# Altium schematic sheet Sheet2.SchDoc(Sheet2)
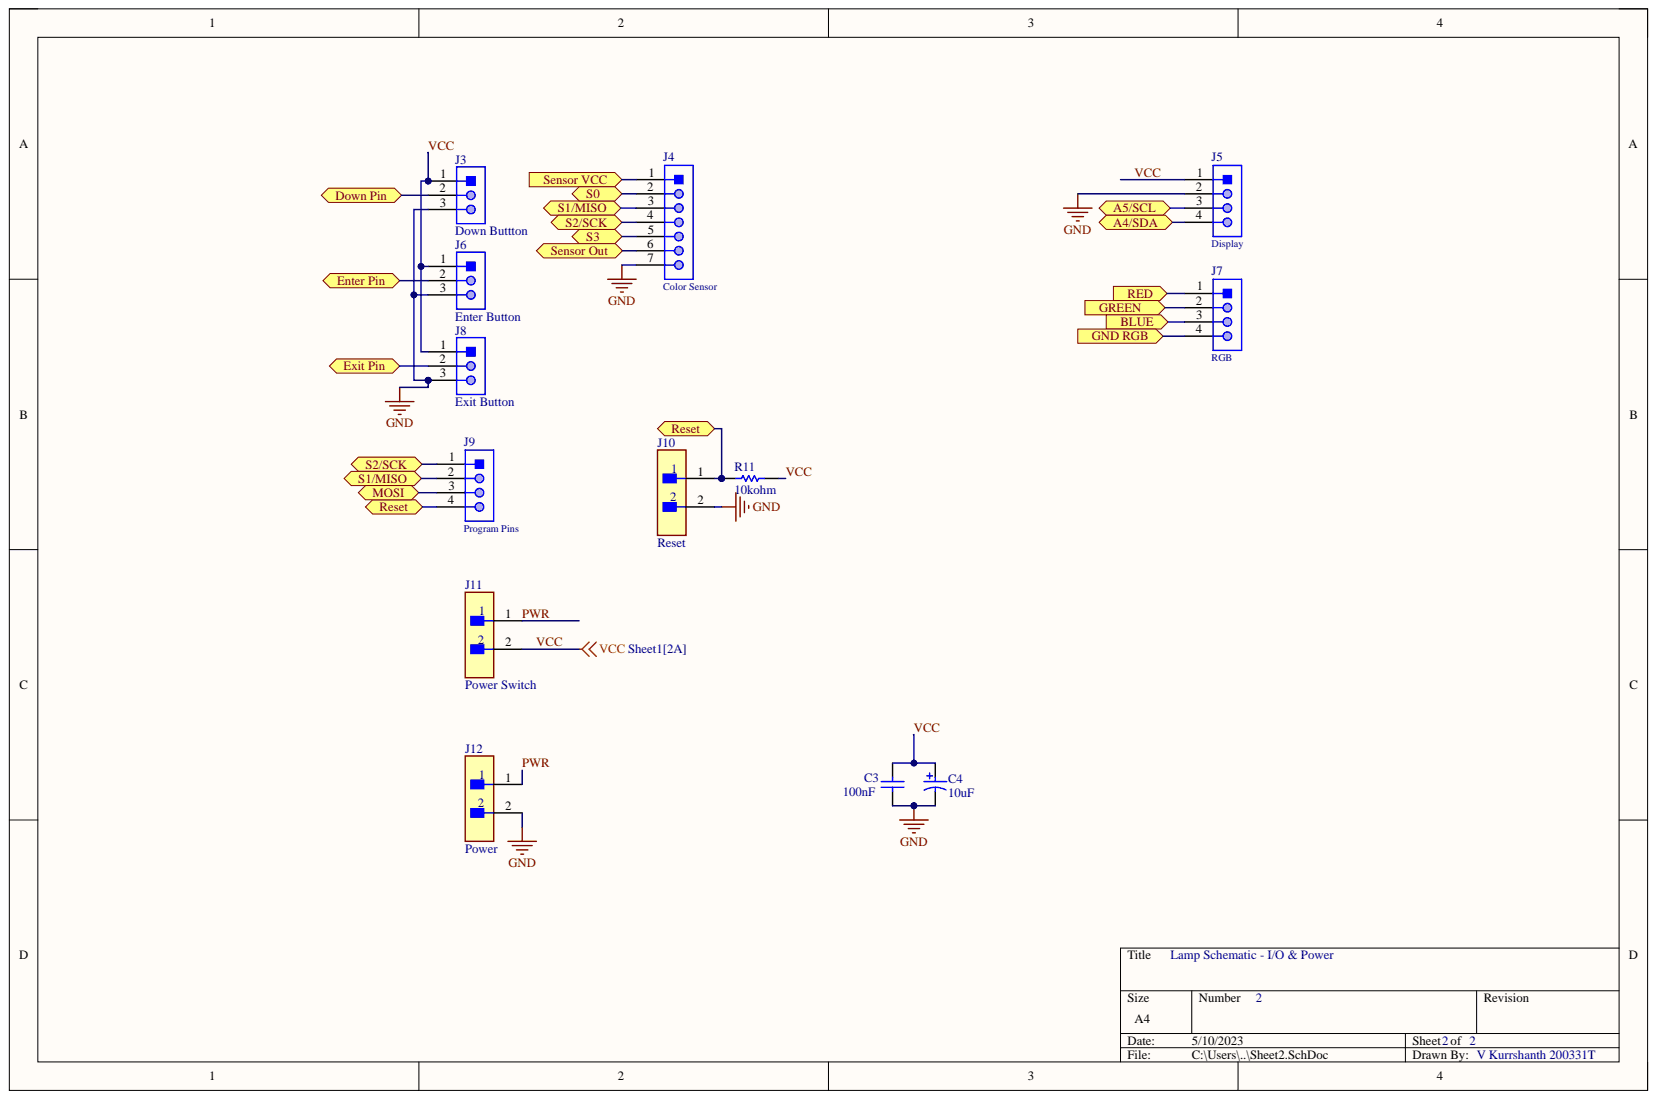
[diagram p0: Sheet2.SchDoc]

## Parts
C3  100nF  [VISH-K104K15X7RF5TL2]
C4  10uF  [CAPPR55-200-1100X500X1200]
J3  Down Buttton  [MOLX-22-28-4033_V]
J4  Color Sensor  [61300711121]
J5  Display  [61300411121]
J6  Enter Button  [MOLX-22-28-4033_V]
J7  RGB  [61300411121]
J8  Exit Button  [MOLX-22-28-4033_V]
J9  Program Pins  [61300411121]
J10  Reset  [FP-M20-9990246-MFG]
J11  Power Switch  [FP-M20-9990246-MFG]
J12  Power  [FP-M20-9990246-MFG]
R11  10kohm  [RESA60-630X240]

## Ports
A4/SDA, A5/SCL, BLUE, Down Pin, Enter Pin, Exit Pin, GND RGB, GREEN, MOSI, RED, Reset, S0, S1/MISO, S2/SCK, S3, Sensor Out, Sensor VCC

## Nets
GND: C3.1 C4.2 J3.3 J4.7 J5.2 J6.3 J8.3 J10.2 J12.2
A4/SDA: J5.4 ; port A4/SDA
A5/SCL: J5.3 ; port A5/SCL
BLUE: J7.3 ; port BLUE
Down Pin: J3.2 ; port Down Pin
Enter Pin: J6.2 ; port Enter Pin
Exit Pin: J8.2 ; port Exit Pin
GND RGB: J7.4 ; port GND RGB
GREEN: J7.2 ; port GREEN
MOSI: J9.3 ; port MOSI
PWR: J11.1 J12.1
RED: J7.1 ; port RED
Reset: J9.4 J10.1 R11.1 ; port Reset
S0: J4.2 ; port S0
S1/MISO: J4.3 J9.2 ; port S1/MISO
S2/SCK: J4.4 J9.1 ; port S2/SCK
S3: J4.5 ; port S3
Sensor Out: J4.6 ; port Sensor Out
Sensor VCC: J4.1 ; port Sensor VCC
VCC: C3.2 C4.1 J3.1 J5.1 J6.1 J8.1 J11.2 R11.2

## Library data of the parts
C3 = CMP-2000-06508-1: Ceramic Capacitor, 100 nF, +/- 10%, 50 V, -55 to 125 degC, 2-Pin THD, RoHS, Tape and Reel; Manufacturer: Vishay; Capacitance: 0.1µF; Catalog Drawings: Mono-KapSide2-15_5.58Mono-KapSide1_15; Online Catalog: Mono-Kap™Series; Other Names: BC1084CT; Temperature Coefficient: X7R; Voltage - Rated: 50V; Applications: GeneralPurpose; Category: Capacitors; Component Kind: Standard; Datasheet URL: http://www.vishay.com/docs/45171/kseries.pdf; Datasheet Version: 08/2013; Family: CeramicCapacitors; Features: -; Height - Seated (Max): 0.220"(5.58mm); Lead Spacing: 0.098"(2.50mm); Lead Style: Straight; Library Name: Altium Content Vault; Manufacturer URL: http://www.vishay.com/; Mounting Technology: ThroughHole; Mounting Type: ThroughHole; Operating Temperature: -55°C~125°C; Package / Case: Radial; Package Description: 2-Pin Through Hole Device, Body 4 x 2.6 mm; Package Reference: K104K15X7RF5TL2; Packaging: CutTape(CT); Ratings: -; Series: Mono-Kap™K; Size / Dimension: 0.157"Lx0.098"W(4.00mmx2.50mm); Standard Package: 1; Thickness (Max): -; Tolerance: ±10%
C4 = CMP-1665-00038-2: Aluminum Electrolytic Capacitor, 10 uF, +/- 20%, 50 V, -40 to 85 degC, 2-Pin THD, RoHS, Bulk; Manufacturer: Panasonic; Dielectric Material: Aluminium; Dissipation Factor: 0.12%; Lead Diameter: 0.5mm; Lead/Base Style: Straight; Leakage Current: 3uA; Life (Hours): 2000Hour; Ripple Current: 65mA; Voltage: 50V; Capacitance: 10uF; Case/Package: Radial; Component Kind: Standard; Datasheet URL: https://industrial.panasonic.com/cdbs/www-data/pdf/RDF0000/ABA0000C1218.pdf; Datasheet Version: Rev. 05, 10/2016; Diameter: 5mm; Height: 11mm; Height - Seated (Max): 0.472inch; Lead Free: LeadFree; Lead Pitch: 2mm; Length: 11mm; Library Name: Altium Content Vault; Manufacturer URL: http://www.panasonic.com/; Max Operating Temperature: 85degC; Min Operating Temperature: -40degC; Mount: ThroughHole; Number of Pins: 2; Package Description: 2-Pin Radial Lead, Dia 5 mm, Height 12 mm; Package Quantity: 200; Package Reference: CAPPR55-200-1100X500X1200; Packaging: Bulk; Radiation Hardening: No; REACH SVHC: NoSVHC; Ripple Current (AC): 65mA; RoHSCompliant: true; Termination: Radial; Tolerance: 20%; Voltage Rating: 50V; Voltage Rating (DC): 50V
J3 J6 J8 = CMP-1676-00001-5: Male Header, Pitch 2.54 mm, 1 x 3 Position, Height 8.38 mm, Tail Length 3.18 mm, RoHS, Bulk; Manufacturer: Molex; Current Rating: 4 A; Rows: 1; Voltage Rating: 250 V; Colour: Black; Component Kind: Standard; ComponentLink1Description: Manufacturer URL; ComponentLink1URL: http://www.molex.com/; ComponentLink2Description: Datasheet; ComponentLink2URL: http://www.molex.com/pdm_docs/sd/022284033_sd.pdf; Contact Finish: Gold; Contact Material: Brass; Contact Plating: Gold; DatasheetVersion: Rev. G, 01/2012; Height: 8.38 mm; Lead Pitch: 0.1 inch; Length: 7.62 mm; Library Name: Altium Content Vault; Max Operating Temperature: 75 degC; Min Operating Temperature: 0 degC; Mount: Through Hole; Mounting Technology: Through Hole; Package Quantity: 1; PackageDescription: Male Header, Pitch 2.54 mm, 1 x 3 Position, Height 8.38 mm, Tail Length 3.18 mm; PackageReference: 22-28-4033; Packaging: Bulk; Pins: 3; REACH SVHC: Unknown; RoHSCompliant: true
J4 = CMP-1502-01074-1: THT Vertical Pin Header WR-PHD, Pitch 2.54 mm, Single Row, 7 pins; Manufacturer: Wurth Elektronik; Contact Resistance: 20mOhm; Gender: Male; Pin Number: 7; Pitch: 2.54mm; Rated Current: 3A; Withstanding Voltage: 500VAC; Working Voltage: 250VAC; Component Kind: Standard; Datasheet URL: https://katalog.we-online.de/em/datasheet/6130xx11121.pdf; Library Name: Altium Content Vault; Manufacturer URL: http://www.we-online.de; Mounting Technology: THT; Operating Temperature: -40to105degC; Orientation: Vertical; Package Reference: 61300711121; Packaging: Bag; Part Number: 61300711121; Row Number: Single; Publisher: WurthElektronik
J5 J7 J9 = CMP-1502-01064-1: THT Vertical Pin Header WR-PHD, Pitch 2.54 mm, Single Row, 4 pins; Manufacturer: Wurth Elektronik; Contact Resistance: 20mOhm; Gender: Male; Pin Number: 4; Pitch: 2.54mm; Rated Current: 3A; Withstanding Voltage: 500VAC; Working Voltage: 250VAC; Component Kind: Standard; Datasheet URL: https://katalog.we-online.de/em/datasheet/6130xx11121.pdf; Library Name: Altium Content Vault; Manufacturer URL: http://www.we-online.de; Mounting Technology: THT; Operating Temperature: -40to105degC; Orientation: Vertical; Package Reference: 61300411121; Packaging: Bag; Part Number: 61300411121; Row Number: Single; Publisher: WurthElektronik
J10-J12 = CMP-15831-000009-1: CONN HEADER VERT 2POS 2.54MM; Manufacturer: Harwin; Manufacturer Part Number: M20-9990246; Conn Gender: Male; Conn Orientation: Vertical; Current Rating: 3A; Number Of Contacts: 2; Automotive: No; Category: Conn; Component Kind: Standard; ComponentLink2Description: Datasheet; ComponentLink2URL: https://cdn.harwin.com/pdfs/M20-999.pdf; Contact Material: Tin,Nickel; Device Class L1: Connectors; Device Class L2: Headers and Wire Housings; Device Class L3: unset; Digikey Description: CONN HEADER VERT 2POS 2.54MM; Height: 8.99mm; Lead Free: Yes; Library Name: Altium Content Vault; Mouser Description: 2.54mm (0.1") Pitch SIL Vertical PC Tail Pin Header, 6.1mm mating pin height, tin, 2 contacts; Number Of Rows: 1; Octopart: https://octopart.com/m20-9990246-harwin-2590635; Package: M20-9990246; Pitch: 2.54mm; RoHS: TRUE; Temperature: -40°C to +105°C; Temperature Range High: +105°C; Temperature Range Low: -40°C
R11 = CMP-1659-00074-2: Axial Resistor, 10 KOhm, +/- 1%, 0.25 W, -55 to 155 degC, 2-Pin THD, RoHS, Bulk; Manufacturer: Yageo; Max Power Dissipation: 250mW; Temperature Coefficient: 100ppm\/degreesC; Voltage Rating: 250V; Voltage Rating (DC): 200V; Case\/Package: Axial; Component Kind: Standard; Composition: MetalFilm; Datasheet URL: http://www.yageo.com/documents/recent/Yageo%20LR_MFR_2013.pdf; Datasheet Version: 04/2013; Lead Free: LeadFree; Length: 0.248inch; Library Name: Altium Content Vault; Manufacturer URL: http://www.yageo.com/; Max Operating Temperature: 155degC; Military Standard: Not; Min Operating Temperature: -55degC; Mount: ThroughHole; Mounting Technology: ThroughHole; Number of Terminations: 2; Package Description: 2-Pin Axial Lead, Dia 2.4 mm, Body Length 6.3 mm; Package Quantity: 200; Package Reference: MFR-25; Packaging: Bulk; Power Rating: 250mW; Resistance: 10KOhm; RoHSCompliant: True; Termination: Axial; Tolerance: 1%
